# Altium schematic sheet Sheet3.SchDoc
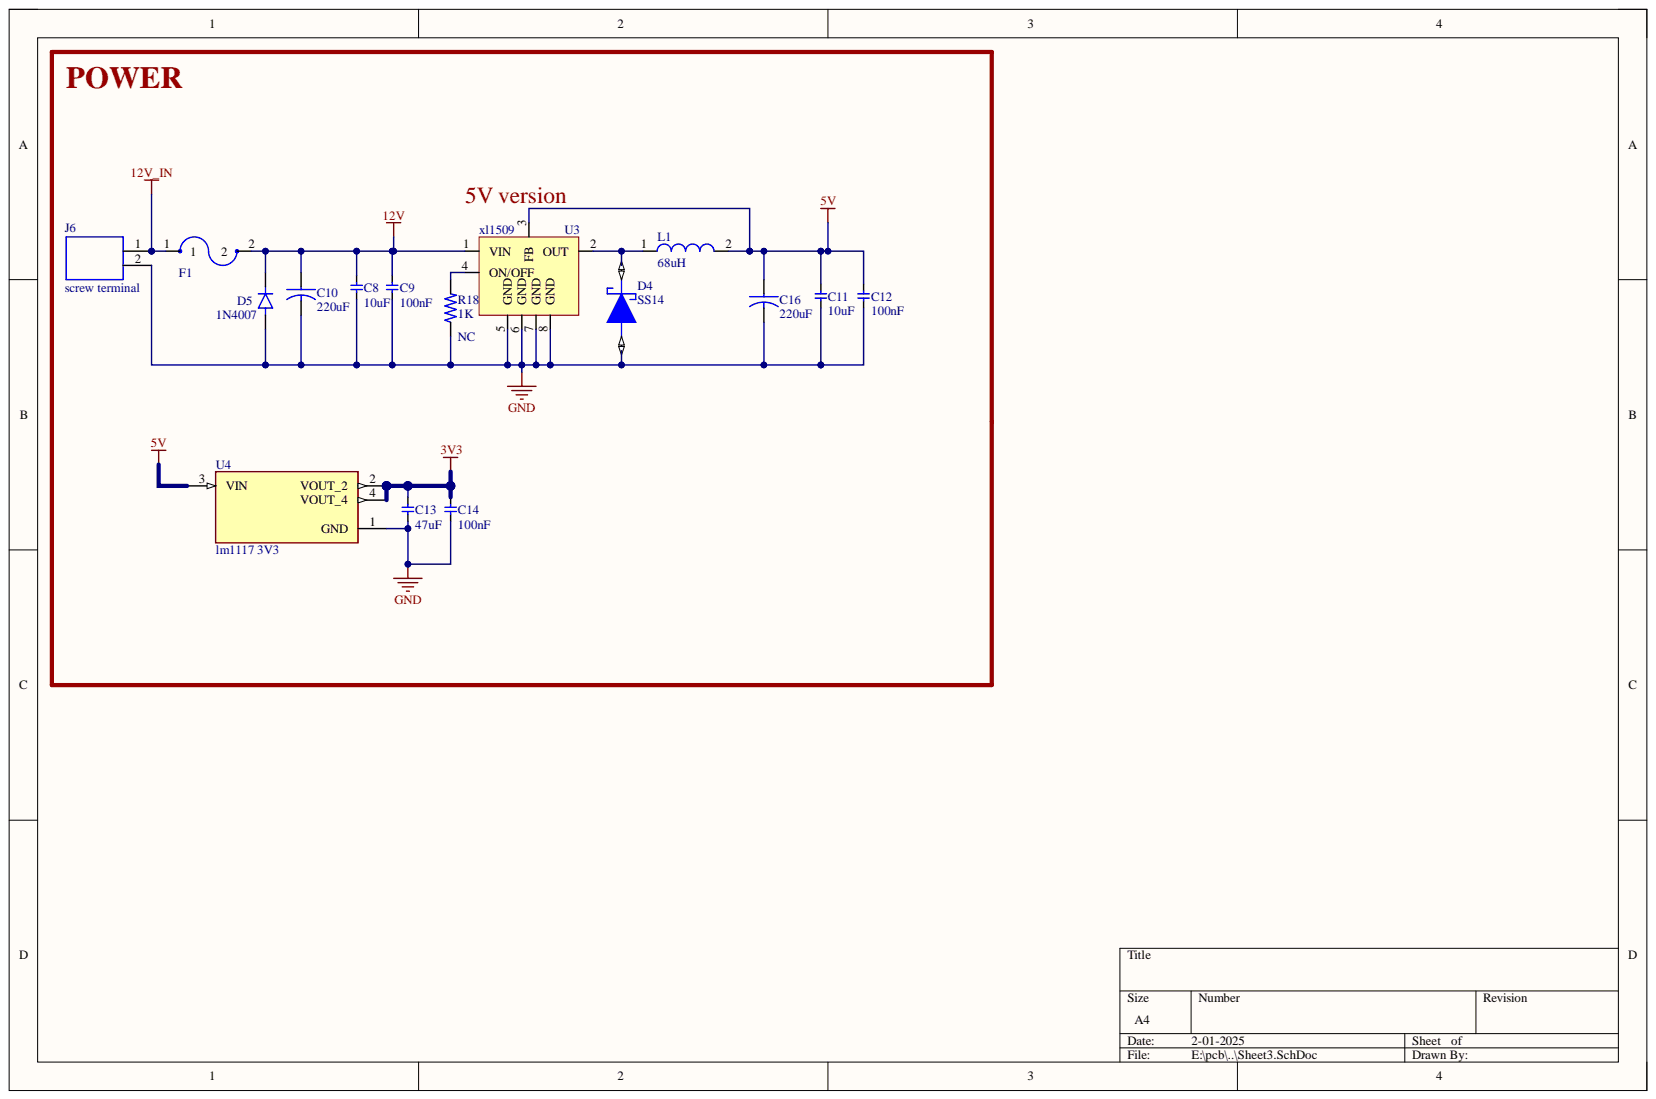
[diagram p0: Sheet3.SchDoc]

## Parts
C8  10uF  [100uF 25V]
C9  100nF  [100nF 16V]
C10  220uF  [220uF 35V SMD ELECTROLYTIC]
C11  10uF  [100uF 25V]
C12  100nF  [100nF 16V]
C13  47uF  [47uF 16V]
C14  100nF  [100nF 16V]
C16  220uF  [220uF 35V SMD ELECTROLYTIC]
D4  SS14  [DIOM5227X270N]
D5  1N4007  [1N4007 SMD]
F1  wt1812  [fuse wt1812]  (value not drawn)
J6  screw terminal  [screw terminal 2 pin]
L1  68uH  [inductor CDRH104R 68uH]
R18  1K  [Resistor 1K 1/4W]
U3  xl1509  [xl1509]
U4  lm1117 3V3  [lm1117 smd]

## Nets
3V3: C13.2 C14.2 U4.2(VOUT_2) U4.4(VOUT_4)
5V: C11.2 C12.2 C16.2 L1.2 U3.3(FB) U4.3(VIN)
12V: C8.2 C9.2 C10.2 D5.C F1.2 U3.1(VIN)
12V_IN: F1.1 J6.1
GND: C8.1 C9.1 C10.1 C11.1 C12.1 C13.1 C14.1 C16.1 D4.2 D5.A J6.2 R18.1 U3.5(GND) U3.6(GND) U3.7(GND) U3.8(GND) U4.1(GND)
NetD4_1: D4.1 L1.1 U3.2(OUT)
NetR18_2: R18.2 U3.4(ON/OFF)

## Library data of the parts
C8 C11 = 10uF: 0805 25V; Component Kind: Standard; Library Name: capacitors.SchLib
C9 C12 C14 = 100nF: 0805 16V; Component Kind: Standard; Library Name: capacitors.SchLib
C10 C16 = 220uF: 35V SMD ELECTROLYTIC; Component Kind: Standard; Library Name: capacitors.SchLib
C13 = 47uF: 0805 25V; Component Kind: Standard; Library Name: capacitors.SchLib
D4 = SS14: Diode Schottky 40 V 1A Surface Mount DO-214AC (SMA); MF: ON Semiconductor; Check_prices: https://www.snapeda.com/parts/SS14/Onsemi/view-part/?ref=eda; Component Kind: Standard; Library Name: diode.SchLib; MP: SS14; Package: SMA-2 ON Semiconductor; SnapEDA_Link: https://www.snapeda.com/parts/SS14/Onsemi/view-part/?ref=snap
D5 = 1N4007: 1N4007 SMD; Component Kind: Standard; Library Name: diode.SchLib
F1 = fuse wt1812: WT1812-300/16 16V 3A Weite (1812 SMD) PPTC Resettable Fuse; Component Kind: Standard; Library Name: Schlib.SchLib
J6 = screw terminal: screw terminal 2 pin; Component Kind: Standard; Library Name: Schlib.SchLib
L1 = inductor 68uH: CDRH104R 68uH (680) SMD Power Inductor; Component Kind: Standard; Library Name: Schlib.SchLib
R18 = Resistor: Resistor 1K 1/4W 0805 package; Component Kind: Standard; Library Name: Schlib.SchLib
U3 = xl1509: xl1509 5.0 version; Component Kind: Standard; Library Name: Schlib.SchLib
U4 = lm1117 3V3: Component Kind: Standard; Library Name: buck.SchLib
